# Altium schematic sheet 04_Sch.SchDoc
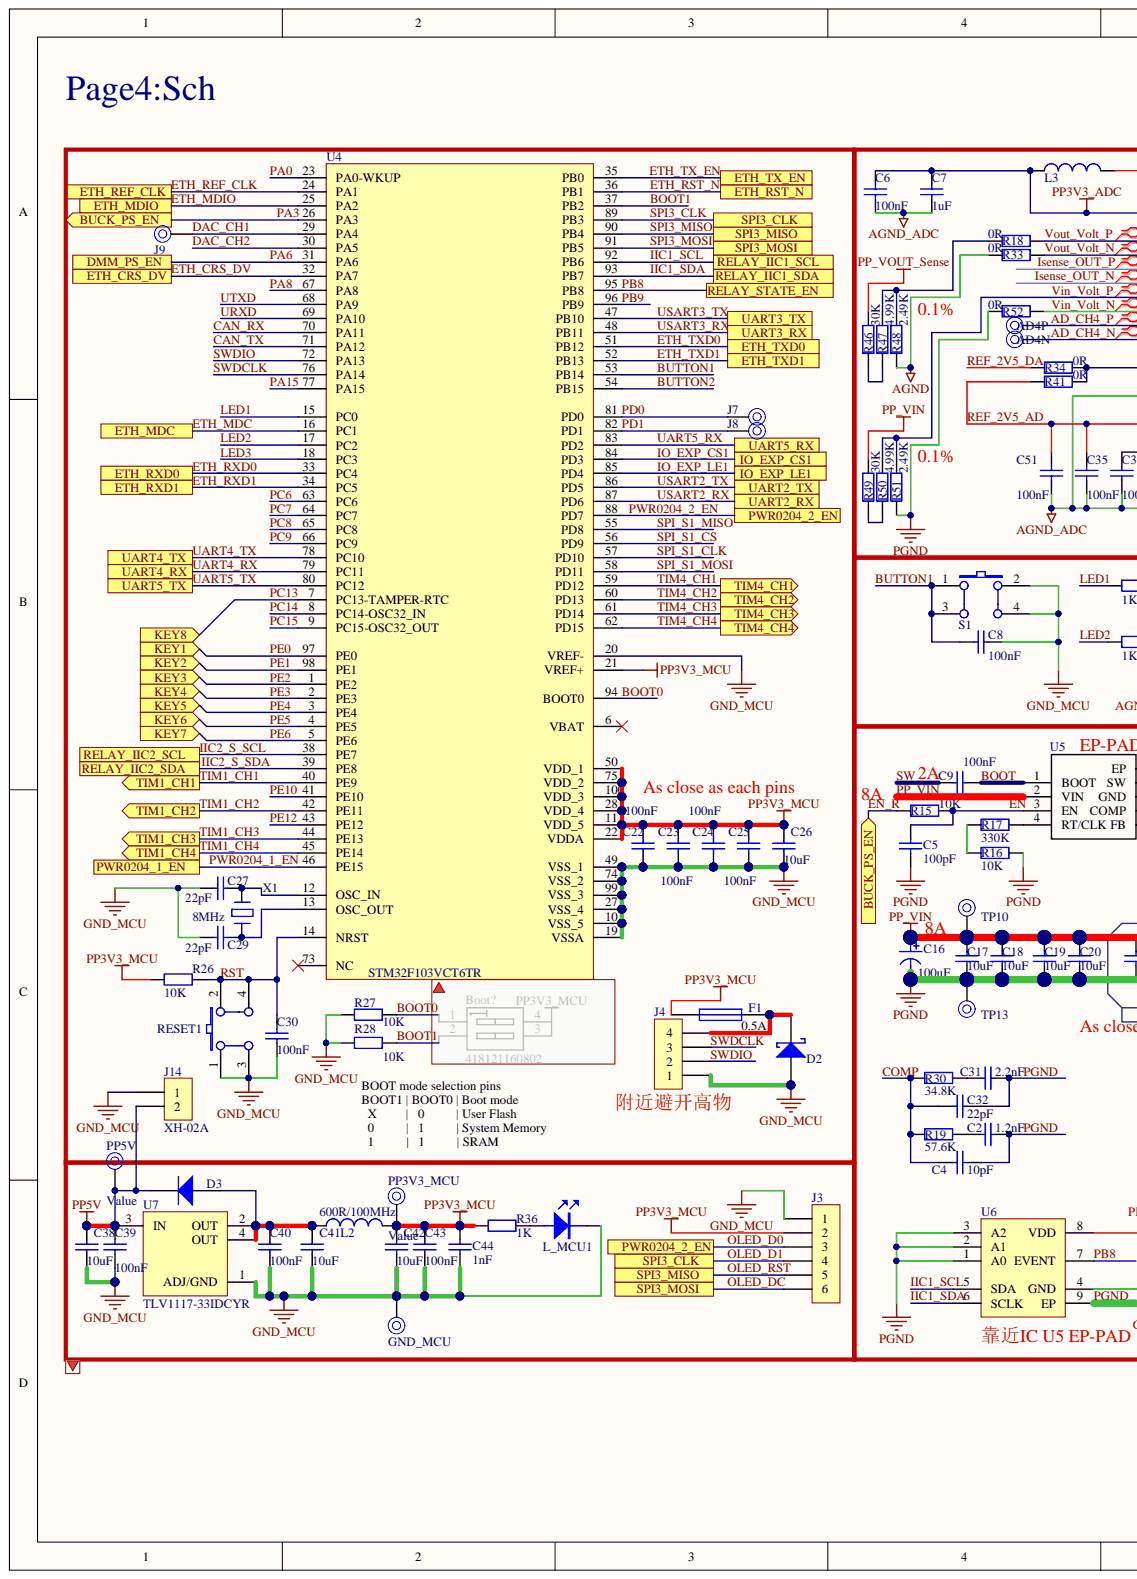
[diagram p0: 04_Sch.SchDoc, left]
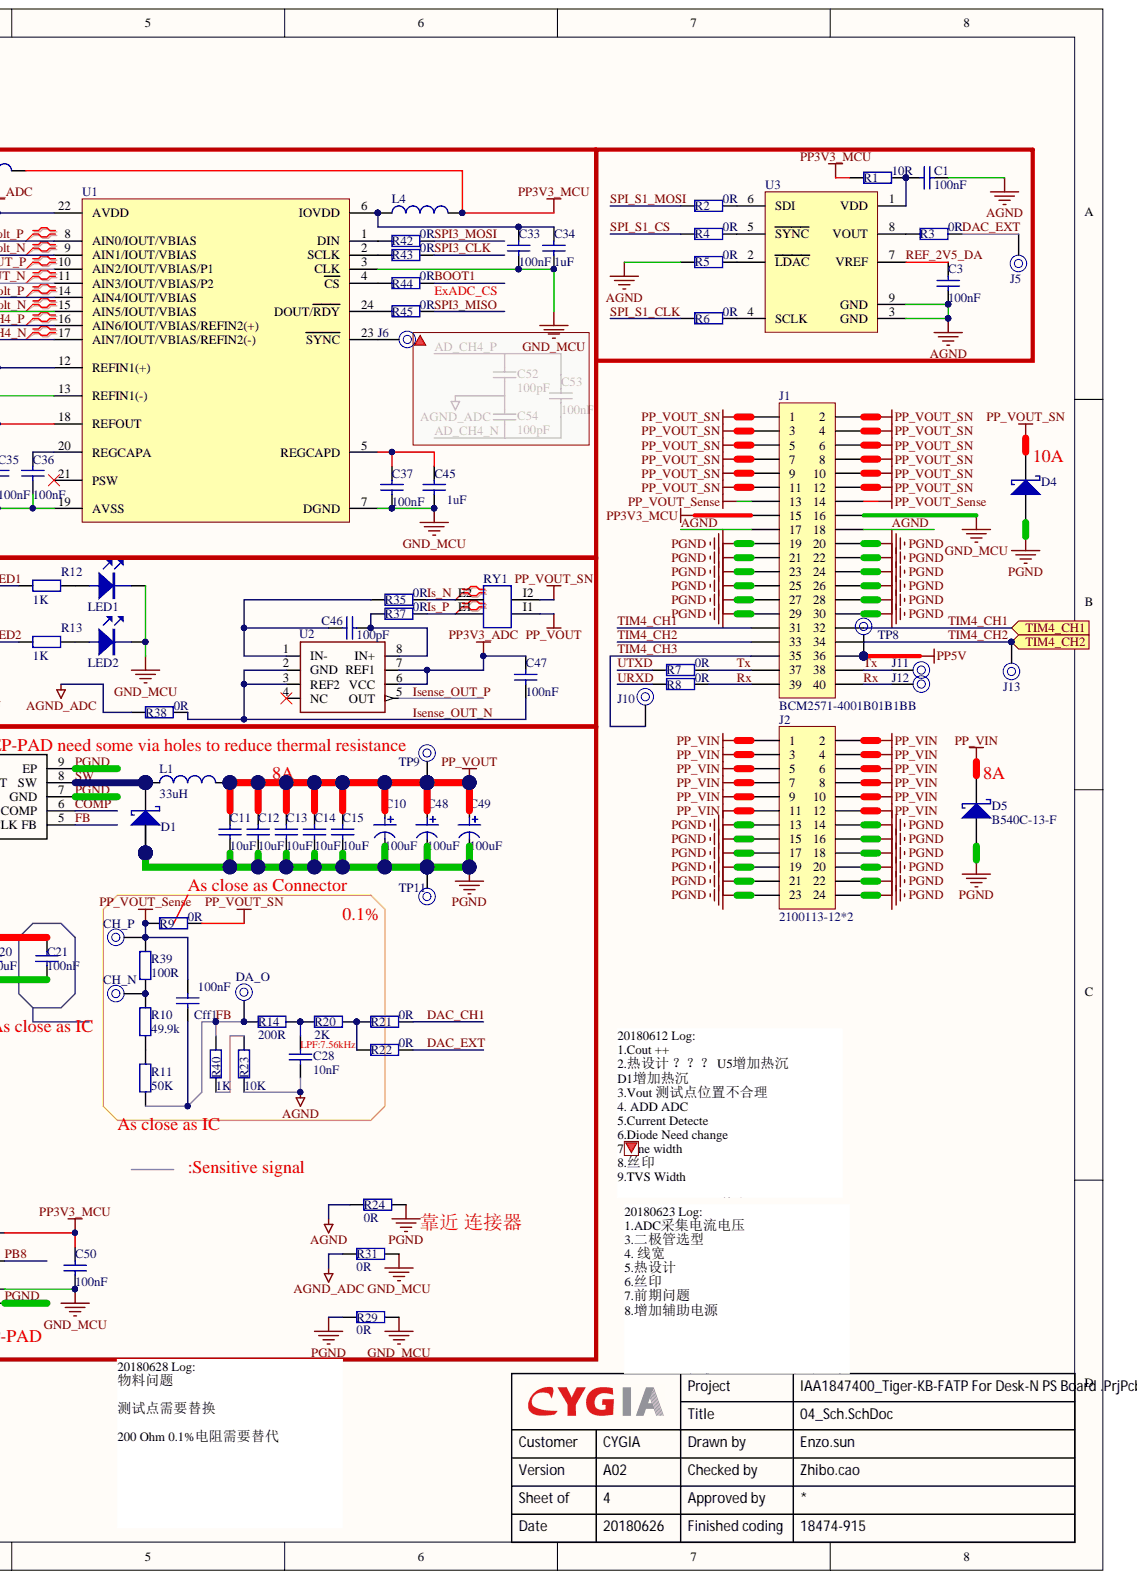
[diagram p1: 04_Sch.SchDoc, right]

## Parts
AD4N  5015  [TP-2610-SM]  (value not drawn)
AD4P  5015  [TP-2610-SM]  (value not drawn)
C1  100nF  [C0603]
C2  1.2nF  [C0603]
C3  100nF  [C0603]
C4  10pF  [C0603]
C5  100pF  [C0603]
C6  100nF  [C0603]
C7  1uF  [C0603]
C8  100nF  [C0603]
C9  100nF  [C0603]
C10  100uF  [CES-6R3x5R8mm]
C11  10uF  [C1206]
C12  10uF  [C1206]
C13  10uF  [C1206]
C14  10uF  [C1206]
C15  10uF  [C1206]
C16  100uF  [CES-8x10R2mm]
C17  10uF  [C1206]
C18  10uF  [C1206]
C19  10uF  [C1206]
C20  10uF  [C1206]
C21  100nF  [C0603]
C22  100nF  [C0603]
C23  100nF  [C0603]
C24  100nF  [C0603]
C25  100nF  [C0603]
C26  10uF  [C0805]
C27  22pF  [C0603]
C28  10nF  [C0603]
C29  22pF  [C0603]
C30  100nF  [C0603]
C31  2.2nF  [C0603]
C32  22pF  [C0603]
C33  100nF  [C0603]
C34  1uF  [C0603]
C35  100nF  [C0603]
C36  100nF  [C0603]
C37  100nF  [C0603]
C38  10uF  [C0805]
C39  100nF  [C0603]
C40  100nF  [C0603]
C41  10uF  [C0805]
C42  10uF  [C0805]
C43  100nF  [C0603]
C44  1nF  [C0603]
C45  1uF  [C0603]
C46  100pF  [C0603]
C47  100nF  [C0603]
C48  100uF  [CES-6R3x5R8mm]
C49  100uF  [CES-6R3x5R8mm]
C50  100nF  [C0603]
C51  100nF  [C0603]
Cff1  100nF  [C0603]
CH_N  Value  [TP-1R5-SM]  (value not drawn)
CH_P  Value  [TP-1R5-SM]  (value not drawn)
D1  STPS20M100SG-TR  [DDPAK]  (value not drawn)
D2  NSR0320MW2T1G  [SOD-323]  (value not drawn)
D3  1N4148WS  [SOD-323]  (value not drawn)
D4  B540C-13-F  [SMC]
D5  B540C-13-F  [SMC]
DA_O  Value  [TP-1R5-SM]  (value not drawn)
F1  SMD0805-050-6V  [FUSE-0805]  (value not drawn)
GND_MCU  Value  [TP-1R5-SM]  (value not drawn)
J1  BCM2571-4001B01B1BB  [B2B-BCM2571-4001B01B1BB]
J2  2100113-12*2  [B2B-2100113-12x2]
J3  61300611821  [CON6R-2R54-TH]  (value not drawn)
J4  PAS1251-0401A01B1BA  [CON4P-2R54-TH]  (value not drawn)
J5  Value  [TP-1R5-SM]  (value not drawn)
J6  Value  [TP-1R5-SM]  (value not drawn)
J7  Value  [TP-1R5-SM]  (value not drawn)
J8  Value  [TP-1R5-SM]  (value not drawn)
J9  Value  [TP-1R5-SM]  (value not drawn)
J10  Value  [TP-1R5-SM]  (value not drawn)
J11  Value  [TP-1R5-SM]  (value not drawn)
J12  Value  [TP-1R5-SM]  (value not drawn)
J13  Value  [TP-1R5-SM]  (value not drawn)
J14  XH-02A  [XH2P-2R54-TH]
L1  33uH  [IND17R15X17R15X7-2]
L2  600R/100MHz  [L0805]
L3  470R/100MHz  [L0603]  (value not drawn)
L4  470R/100MHz  [L0603]  (value not drawn)
L_MCU1  19-213UYC/S530-A3/TR8  [LED-0603]  (value not drawn)
LED1  19-213UYC/S530-A3/TR8  [LED-0603]  (value not drawn)
LED2  19-213UYC/S530-A3/TR8  [LED-0603]  (value not drawn)
PP3V3_MCU  Value  [TP-1R5-SM]
PP5V  Value  [TP-1R5-SM]
R1  10R  [R0603]
R2  0R  [R0402]
R3  0R  [R0402]
R4  0R  [R0402]
R5  0R  [R0402]
R6  0R  [R0402]
R7  0R  [R0402]
R8  0R  [R0402]
R9  0R  [R0402]
R10  49.9k  [R0603]
R11  50K  [R0603]
R12  1K  [R0603]
R13  1K  [R0603]
R14  200R  [R0603]
R15  10K  [R0603]
R16  10K  [R0603]
R17  330K  [R0603]
R18  0R  [R0402]
R19  57.6K  [R0603]
R20  2K  [R0603]
R21  0R  [R0402]
R22  0R  [R0402]
R23  10K  [R0603]
R24  0R  [R0603]
R26  10K  [R0603]
R27  10K  [R0603]
R28  10K  [R0603]
R29  0R  [R0603]
R30  34.8K  [R0603]
R31  0R  [R0603]
R33  0R  [R0402]
R34  0R  [R0402]
R35  0R  [R0402]
R36  1K  [R0603]
R37  0R  [R0402]
R38  0R  [R0603]
R39  100R  [R0603]
R40  1K  [R0603]
R41  0R  [R0402]
R42  0R  [R0402]
R43  0R  [R0402]
R44  0R  [R0402]
R45  0R  [R0402]
R46  30K  [R0603]
R47  4.99K  [R0603]
R48  2.49K  [R0603]
R49  30K  [R0603]
R50  4.99K  [R0603]
R51  2.49K  [R0603]
R52  0R  [R0402]
RESET1  430182043816  [SW-SMD-6R2X6R2X4R3-1]  (value not drawn)
RY1  0.005R  [RKY1488]  (value not drawn)
S1  430182043816  [SW-SMD-6R2X6R2X4R3-1]  (value not drawn)
TP8  5015  [TP-2610-SM]  (value not drawn)
TP9  5015  [TP-2610-SM]  (value not drawn)
TP10  5015  [TP-2610-SM]  (value not drawn)
TP11  5015  [TP-2610-SM]  (value not drawn)
TP13  5015  [TP-2610-SM]  (value not drawn)
U1  AD7124-4BRUZ  [TSSOP24-0R65-7R8X4R4]  (value not drawn)
U2  INA282AID  [so-8]  (value not drawn)
U3  AD5683RACPZ-RL7  [LFCSP8-0R5-2X2-T]  (value not drawn)
U4  STM32F103VCT6TR  [LQFP100-0R50-14X14]
U5  U1  [R-PDSO-G8]  (value not drawn)
U6  Categories: IC  [DFN8-0R5-2X3-T]  (hidden comment: MCP9805T-BE/MC)
U7  TLV1117-33IDCYR  [SOT223-4]
X1  8MHz  [XTALHC-49S]

## Ports
BUCK_PS_EN, DMM_PS_EN, ETH_CRS_DV, ETH_MDC, ETH_MDIO, ETH_REF_CLK, ETH_RST_N, ETH_RXD0, ETH_RXD1, ETH_TX_EN, ETH_TXD0, ETH_TXD1, IO_EXP_CS1, IO_EXP_LE1, KEY1, KEY2, KEY3, KEY4, KEY5, KEY6, KEY7, KEY8, PWR0204_1_EN, PWR0204_2_EN, RELAY_IIC1_SCL, RELAY_IIC1_SDA, RELAY_IIC2_SCL, RELAY_IIC2_SDA, RELAY_STATE_EN, SPI3_CLK, SPI3_MISO, SPI3_MOSI, TIM1_CH1, TIM1_CH2, TIM1_CH3, TIM1_CH4, TIM4_CH1, TIM4_CH2, TIM4_CH3, TIM4_CH4, UART2_RX, UART2_TX, UART3_RX, UART3_TX, UART4_RX, UART4_TX, UART5_RX, UART5_TX

## Nets
AGND_ADC: C6.1 C7.2 C35.1 C36.1 C51.1 R31.2 R38.1 U1.13(REFIN1(-)) U1.19(AVSS)
GND_MCU: C8.2 C22.2 C23.2 C24.2 C25.2 C26.2 C27.1 C29.1 C30.1 C33.1 C34.2 C37.1 C38.2 C39.2 C40.2 C41.2 C42.2 C43.2 C44.2 C45.2 C50.1 D2.1 GND_MCU.1 J1.16 J3.1 J4.1 J14.1 L_MCU1.2 LED1.2 LED2.2 R27.2 R28.2 R29.1 R31.1 RESET1.1 RESET1.3 S1.2 S1.4 U1.3(CLK) U1.7(DGND) U4.10(VSS_5) U4.19(VSSA) U4.20(VREF-) U4.27(VSS_4) U4.49(VSS_1) U4.74(VSS_2) U4.99(VSS_3) U6.4(GND) U7.1(ADJ/GND)
PP3V3_ADC: C6.2 C7.1 C47.2 L3.1 U1.22(AVDD) U2.6(VCC) U2.7(REF1)
PP3V3_MCU: C22.1 C23.1 C24.1 C25.1 C26.1 C42.1 C43.1 C44.1 C50.2 F1.2 J1.15 J3.2 L2.1 L3.2 L4.2 PP3V3_MCU.1 R1.1 R26.2 R36.1 U4.11(VDD_5) U4.21(VREF+) U4.22(VDDA) U4.28(VDD_4) U4.50(VDD_1) U4.75(VDD_2) U4.100(VDD_3) U6.8(VDD)
PP5V: C38.1 C39.1 D3.2 J1.36 J14.2 PP5V.1 TP8.1 U7.3(IN)
PP_VOUT: C10.1 C11.1 C12.1 C13.1 C14.1 C15.1 C48.1 C49.1 L1.2 RY1.I1 TP9.1
PP_VOUT_Sense: Cff1.1 CH_P.1 J1.13 J1.14 R9.2 R39.2 R46.2
PP_VOUT_SN: D4.2 J1.1 J1.2 J1.3 J1.4 J1.5 J1.6 J1.7 J1.8 J1.9 J1.10 J1.11 J1.12 R9.1 RY1.I2
AD_CH4_N: AD4N.1 U1.17(AIN7/IOUT/VBIAS/REFIN2(-))
AD_CH4_P: AD4P.1 U1.16(AIN6/IOUT/VBIAS/REFIN2(+))
AGND: C1.2 C3.1 C28.2 J1.17 J1.18 R5.2 R23.2 R24.1 R33.2 R48.1 U3.3(GND) U3.9(GND)
BOOT: C9.1 U5.1(BOOT)
BOOT0: R27.1 U4.94(BOOT0)
BOOT1: R28.1 R44.1 U4.37(PB2)
BUTTON1: C8.1 S1.1 S1.3 U4.53(PB14)
BUTTON2: U4.54(PB15)
CAN_RX: U4.70(PA11)
CAN_TX: U4.71(PA12)
COMP: C4.1 C32.1 R19.1 R30.1 U5.6(COMP)
DAC_CH1: J9.1 R21.1 U4.29(PA4)
DAC_CH2: U4.30(PA5)
DAC_EXT: J5.1 R3.1 R22.1
EN: C5.1 R15.1 U5.3(EN)
EN_R: R15.2 U4.26(PA3) ; port BUCK_PS_EN
ETH_CRS_DV: U4.32(PA7) ; port ETH_CRS_DV
ETH_MDC: U4.16(PC1) ; port ETH_MDC
ETH_MDIO: U4.25(PA2) ; port ETH_MDIO
ETH_REF_CLK: U4.24(PA1) ; port ETH_REF_CLK
ETH_RST_N: U4.36(PB1) ; port ETH_RST_N
ETH_RXD0: U4.33(PC4) ; port ETH_RXD0
ETH_RXD1: U4.34(PC5) ; port ETH_RXD1
ETH_TX_EN: U4.35(PB0) ; port ETH_TX_EN
ETH_TXD0: U4.51(PB12) ; port ETH_TXD0
ETH_TXD1: U4.52(PB13) ; port ETH_TXD1
FB: Cff1.2 DA_O.1 R11.1 R14.1 R40.2 U5.5(FB)
IIC1_SCL: U4.92(PB6) U6.5(SDA) ; port RELAY_IIC1_SCL
IIC1_SDA: U4.93(PB7) U6.6(SCLK) ; port RELAY_IIC1_SDA
IIC2_S_SCL: U4.38(PE7) ; port RELAY_IIC2_SCL
IIC2_S_SDA: U4.39(PE8) ; port RELAY_IIC2_SDA
IO_EXP_CS1: U4.84(PD3) ; port IO_EXP_CS1
IO_EXP_LE1: U4.85(PD4) ; port IO_EXP_LE1
Is_N: R35.1 RY1.E2
Is_P: R37.1 RY1.E1
Isense_OUT_N: C47.1 R38.2 U1.11(AIN3/IOUT/VBIAS/P2) U2.2(GND) U2.3(REF2)
Isense_OUT_P: U1.10(AIN2/IOUT/VBIAS/P1) U2.5(OUT)
LED1: R12.1 U4.15(PC0)
LED2: R13.1 U4.17(PC2)
LED3: U4.18(PC3)
OLED_D0: J3.3 U4.88(PD7) ; port PWR0204_2_EN
OLED_D1: J3.4 R43.1 U4.89(PB3) ; port SPI3_CLK
OLED_DC: J3.6 R42.1 U4.91(PB5) ; port SPI3_MOSI
OLED_RST: J3.5 R45.1 U4.90(PB4) ; port SPI3_MISO
PA0: U4.23(PA0-WKUP)
PA6: U4.31(PA6) ; port DMM_PS_EN
PA8: U4.67(PA8)
PA15: U4.77(PA15)
PB8: U4.95(PB8) U6.7(EVENT) ; port RELAY_STATE_EN
PB9: U4.96(PB9)
PC6: U4.63(PC6)
PC7: U4.64(PC7)
PC8: U4.65(PC8)
PC9: U4.66(PC9)
PC13: U4.7(PC13-TAMPER-RTC) ; port KEY8
PC14: U4.8(PC14-OSC32_IN)
PC15: U4.9(PC15-OSC32_OUT)
PD0: J7.1 U4.81(PD0)
PD1: J8.1 U4.82(PD1)
PE0: U4.97(PE0) ; port KEY1
PE1: U4.98(PE1) ; port KEY2
PE2: U4.1(PE2) ; port KEY3
PE3: U4.2(PE3) ; port KEY4
PE4: U4.3(PE4) ; port KEY5
PE5: U4.4(PE5) ; port KEY6
PE6: U4.5(PE6) ; port KEY7
PE10: U4.41(PE10)
PE12: U4.43(PE12)
PGND: C2.2 C4.2 C5.2 C10.2 C11.2 C12.2 C13.2 C14.2 C15.2 C16.2 C17.2 C18.2 C19.2 C20.2 C21.1 C31.2 C32.2 C48.2 C49.2 D1.1 D1.3 D4.1 D5.1 J1.19 J1.20 J1.21 J1.22 J1.23 J1.24 J1.25 J1.26 J1.27 J1.28 J1.29 J1.30 J2.13 J2.14 J2.15 J2.16 J2.17 J2.18 J2.19 J2.20 J2.21 J2.22 J2.23 J2.24 R16.2 R24.2 R29.2 R51.1 R52.2 TP11.1 TP13.1 U5.7(GND) U5.9(EP) U6.1(A0) U6.2(A1) U6.3(A2) U6.9(EP)
PP_VIN: C16.1 C17.1 C18.1 C19.1 C20.1 C21.2 D5.2 J2.1 J2.2 J2.3 J2.4 J2.5 J2.6 J2.7 J2.8 J2.9 J2.10 J2.11 J2.12 R49.2 TP10.1 U5.2(VIN)
PWR0204_1_EN: U4.46(PE15) ; port PWR0204_1_EN
REF_2V5_AD: C35.2 C51.2 R41.2 U1.18(REFOUT)
REF_2V5_DA: C3.2 R34.2 U3.7(VREF)
RST: C30.2 R26.1 RESET1.2 RESET1.4 U4.14(NRST)
Rx: J1.39 J1.40 J12.1 R8.1
SPI_S1_CLK: R6.2 U4.57(PD10)
SPI_S1_CS: R4.2 U4.56(PD9)
SPI_S1_MISO: U4.55(PD8)
SPI_S1_MOSI: R2.2 U4.58(PD11)
SW: C9.2 D1.2 L1.1 U5.8(SW)
SWDCLK: J4.3 U4.76(PA14)
SWDIO: J4.2 U4.72(PA13)
TIM1_CH1: U4.40(PE9) ; port TIM1_CH1
TIM1_CH2: U4.42(PE11) ; port TIM1_CH2
TIM1_CH3: U4.44(PE13) ; port TIM1_CH3
TIM1_CH4: U4.45(PE14) ; port TIM1_CH4
TIM4_CH1: J1.31 J1.32 U4.59(PD12) ; port TIM4_CH1
TIM4_CH2: J1.33 J1.34 J13.1 U4.60(PD13) ; port TIM4_CH2
TIM4_CH3: J1.35 J10.1 U4.61(PD14) ; port TIM4_CH3
TIM4_CH4: U4.62(PD15) ; port TIM4_CH4
Tx: J1.37 J1.38 J11.1 R7.1
UART4_RX: U4.79(PC11) ; port UART4_RX
UART4_TX: U4.78(PC10) ; port UART4_TX
UART5_RX: U4.83(PD2) ; port UART5_RX
UART5_TX: U4.80(PC12) ; port UART5_TX
URXD: R8.2 U4.69(PA10)
USART2_RX: U4.87(PD6) ; port UART2_RX
USART2_TX: U4.86(PD5) ; port UART2_TX
USART3_RX: U4.48(PB11) ; port UART3_RX
USART3_TX: U4.47(PB10) ; port UART3_TX
UTXD: R7.2 U4.68(PA9)
Vin_Volt_N: R52.1 U1.15(AIN5/IOUT/VBIAS)
Vin_Volt_P: R50.2 R51.2 U1.14(AIN4/IOUT/VBIAS)
Vout_Volt_N: R33.1 U1.9(AIN1/IOUT/VBIAS)
Vout_Volt_P: R18.1 U1.8(AIN0/IOUT/VBIAS)
NetC1_1: C1.1 R1.2 U3.1(VDD)
NetC2_1: C2.1 R19.2
NetC27_2: C27.2 U4.12(OSC_IN) X1.2
NetC28_1: C28.1 R14.2 R20.1
NetC29_2: C29.2 U4.13(OSC_OUT) X1.1
NetC31_1: C31.1 R30.2
NetC33_2: C33.2 C34.1 L4.1 U1.6(IOVDD)
NetC36_2: C36.2 U1.20(REGCAPA)
NetC37_2: C37.2 C45.1 U1.5(REGCAPD)
NetC40_1: C40.1 C41.1 D3.1 L2.2 U7.2(OUT) U7.4(OUT)
NetC46_1: C46.1 R35.2 U2.1(IN-)
NetC46_2: C46.2 R37.2 U2.8(IN+)
NetCH_N_1: CH_N.1 R10.2 R39.1
NetD2_2: D2.2 F1.1 J4.4
NetJ6_1: J6.1 U1.23(SYNC)
NetL_MCU1_1: L_MCU1.1 R36.2
NetLED1_1: LED1.1 R12.2
NetLED2_1: LED2.1 R13.2
NetR2_1: R2.1 U3.6(SDI)
NetR3_2: R3.2 U3.8(VOUT)
NetR4_1: R4.1 U3.5(SYNC)
NetR5_1: R5.1 U3.2(LDAC)
NetR6_1: R6.1 U3.4(SCLK)
NetR10_1: R10.1 R11.2
NetR16_1: R16.1 R17.1
NetR17_2: R17.2 U5.4(RT/CLK)
NetR18_2: R18.2 R47.2 R48.2
NetR20_2: R20.2 R21.2 R22.2
NetR23_1: R23.1 R40.1
NetR34_1: R34.1 R41.1 U1.12(REFIN1(+))
NetR42_2: R42.2 U1.1(DIN)
NetR43_2: R43.2 U1.2(SCLK)
NetR44_2: R44.2 U1.4(CS)
NetR45_2: R45.2 U1.24(DOUT/RDY)
NetR46_1: R46.1 R47.1
NetR49_1: R49.1 R50.1

Not connected: U1 21(PSW); U2 4(NC); U4 6(VBAT) 73(NC)
